# Altium schematic sheet Canbus.SchDoc(Canbus)
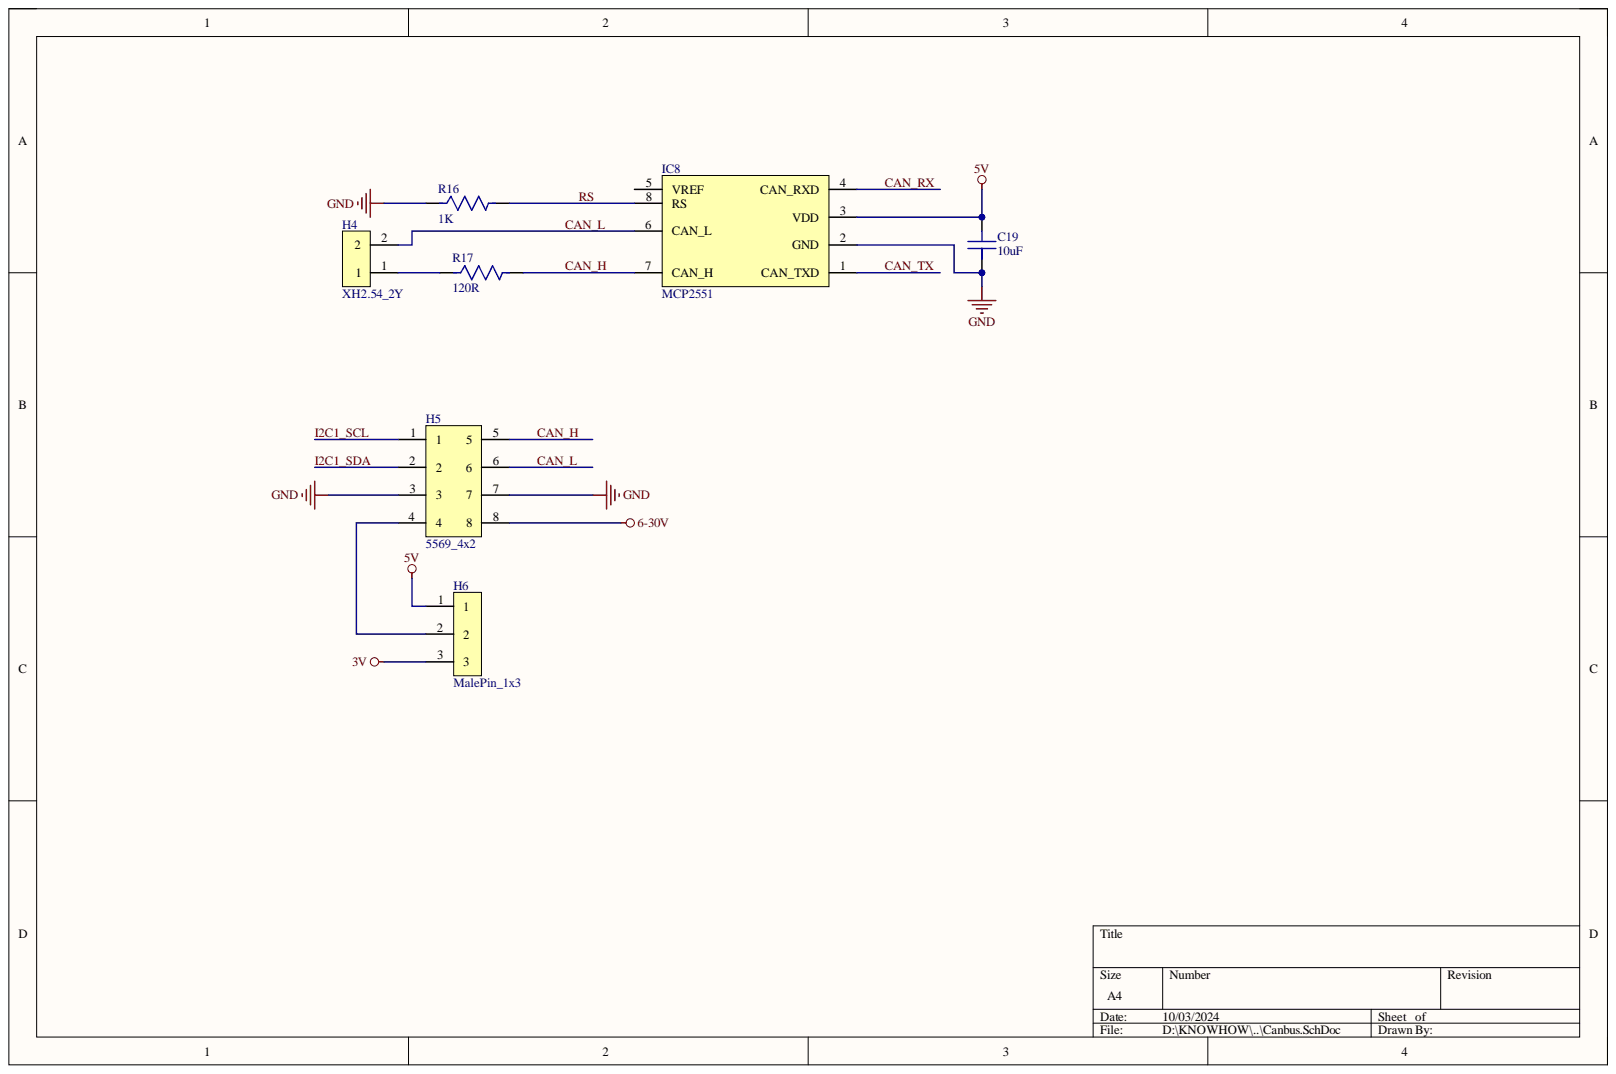
[diagram p0: Canbus.SchDoc]

## Parts
C19  10uF  [C0603]
H4  XH2.54_2Y  [JST-XH-02-PACKAGE-LONG-PAD]
H5  5569_4x2  [5569_4x2]
H6  MalePin_1x3  [MALEPIN_1x3]
IC8  MCP2551  [SOIC-8]
R16  1K  [R0603]
R17  120R  [R0603]

## Nets
3V: H6.3
5V: C19.2 H6.1 IC8.3(VDD)
6-30V: H5.8
GND: C19.1 H5.3 H5.7 IC8.2(GND) R16.1
CAN_H: H5.5 IC8.7(CAN_H) R17.2
CAN_L: H4.2 H5.6 IC8.6(CAN_L)
CAN_RX: IC8.4(CAN_RXD)
CAN_TX: IC8.1(CAN_TXD)
I2C1_SCL: H5.1
I2C1_SDA: H5.2
RS: IC8.8(RS) R16.2
NetH4_1: H4.1 R17.1
NetH5_4: H5.4 H6.2

Not connected: IC8 5(VREF)

## Library data of the parts
C19 = Capacitor 0603: Component Kind: Standard; Library Name: UY_Schlib.SchLib
R16 R17 = Resistor 0603: SMD Resistor 0603; Manufacturer: Yageo; Manufacturer Part Number: RC0603FR-071KL; Max Power Dissipation: 100mW; Resistance Tolerance: 1%; Temperature Coefficient: 100ppm/°C; Voltage Rating: 50V; Case Code (Imperial): 0603; Case Code (Metric): 1608; Case/Package: 0603; Category: Chip SMD Resistors; Component Kind: Standard; Construction: Rectangular; Contact Plating: Tin,Matte; Depth: 0.8mm; Features: Moisture Resistant; Height: 0.45mm; Lead Free: 5697LeadFree; Length: 1.6mm; Max Operating Temperature: 155°C; Military Standard: Not; Min Operating Temperature: -55°C; Number of Terminations: 2; Packaging: Tape and Reel; Power Rating: 100mW; Pricing: 1=0.1, 10=0.018, 50=0.0106, 100=0.0088, 500=0.00592, 1000=0.00505 (USD); Reach SVHC Compliant: No; Resistor Type: Thick Film; RoHS: Yes; RoHS Compliant: Yes; Series: RC; Stock: 18662657; Supplier: Digi-Key; Supplier Part Number: 311-1.00KHRDKR-ND; Technology: THICK FILM; Termination: SMD/SMT; Tolerance: 1%; Library Name: UY_Schlib.SchLib
H4 = XH2.54_2Y: Component Kind: Standard; Description: XH2.54_2Y; Library Name: UY_JST_XH2.54.SchLib
H5 = 5569_4x2: Component Kind: Standard; Library Name: UY_5569_Header.SchLib
H6 = MalePin_1x3: Component Kind: Standard; Library Name: UY_MalePin2.54.SchLib
IC8 = MCP2551: Component Kind: Standard; Library Name: UY_Schlib.SchLib
